# Altium schematic sheet RL-17-003-STP-HB-Mainsheet.SchDoc
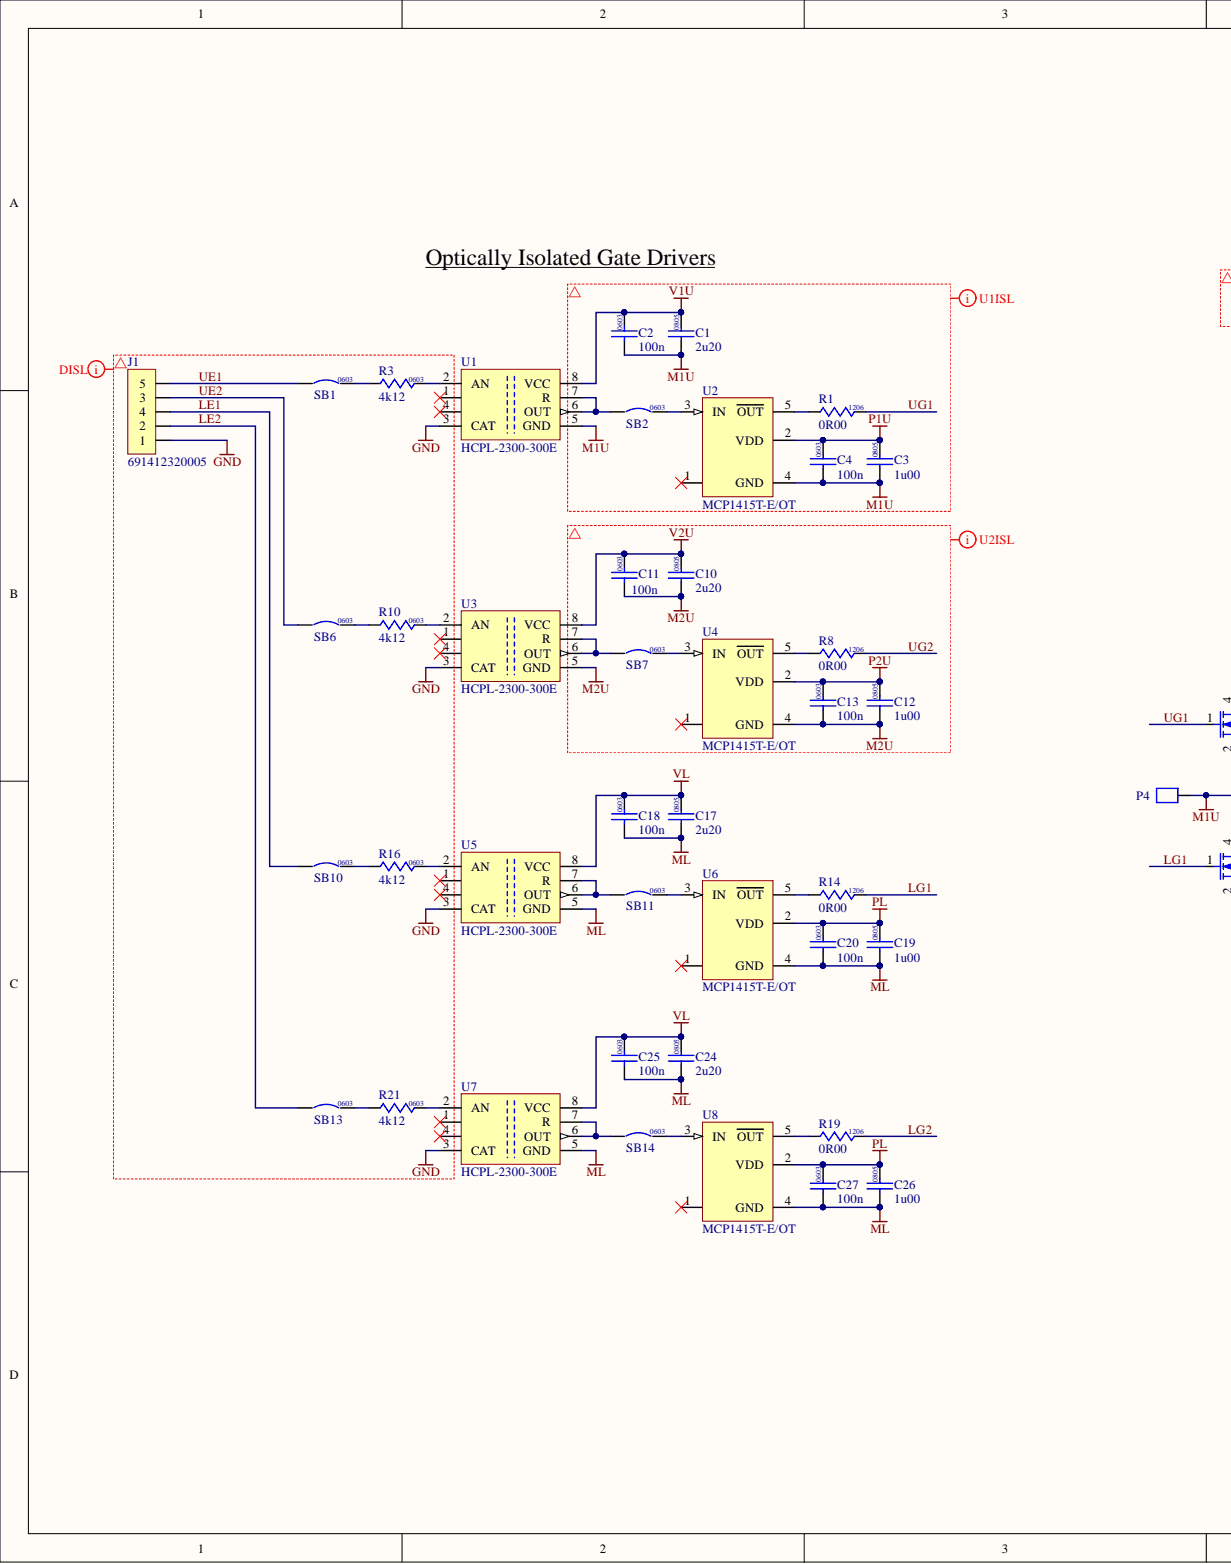
[diagram p0: RL-17-003-STP-HB-Mainsheet.SchDoc, left]
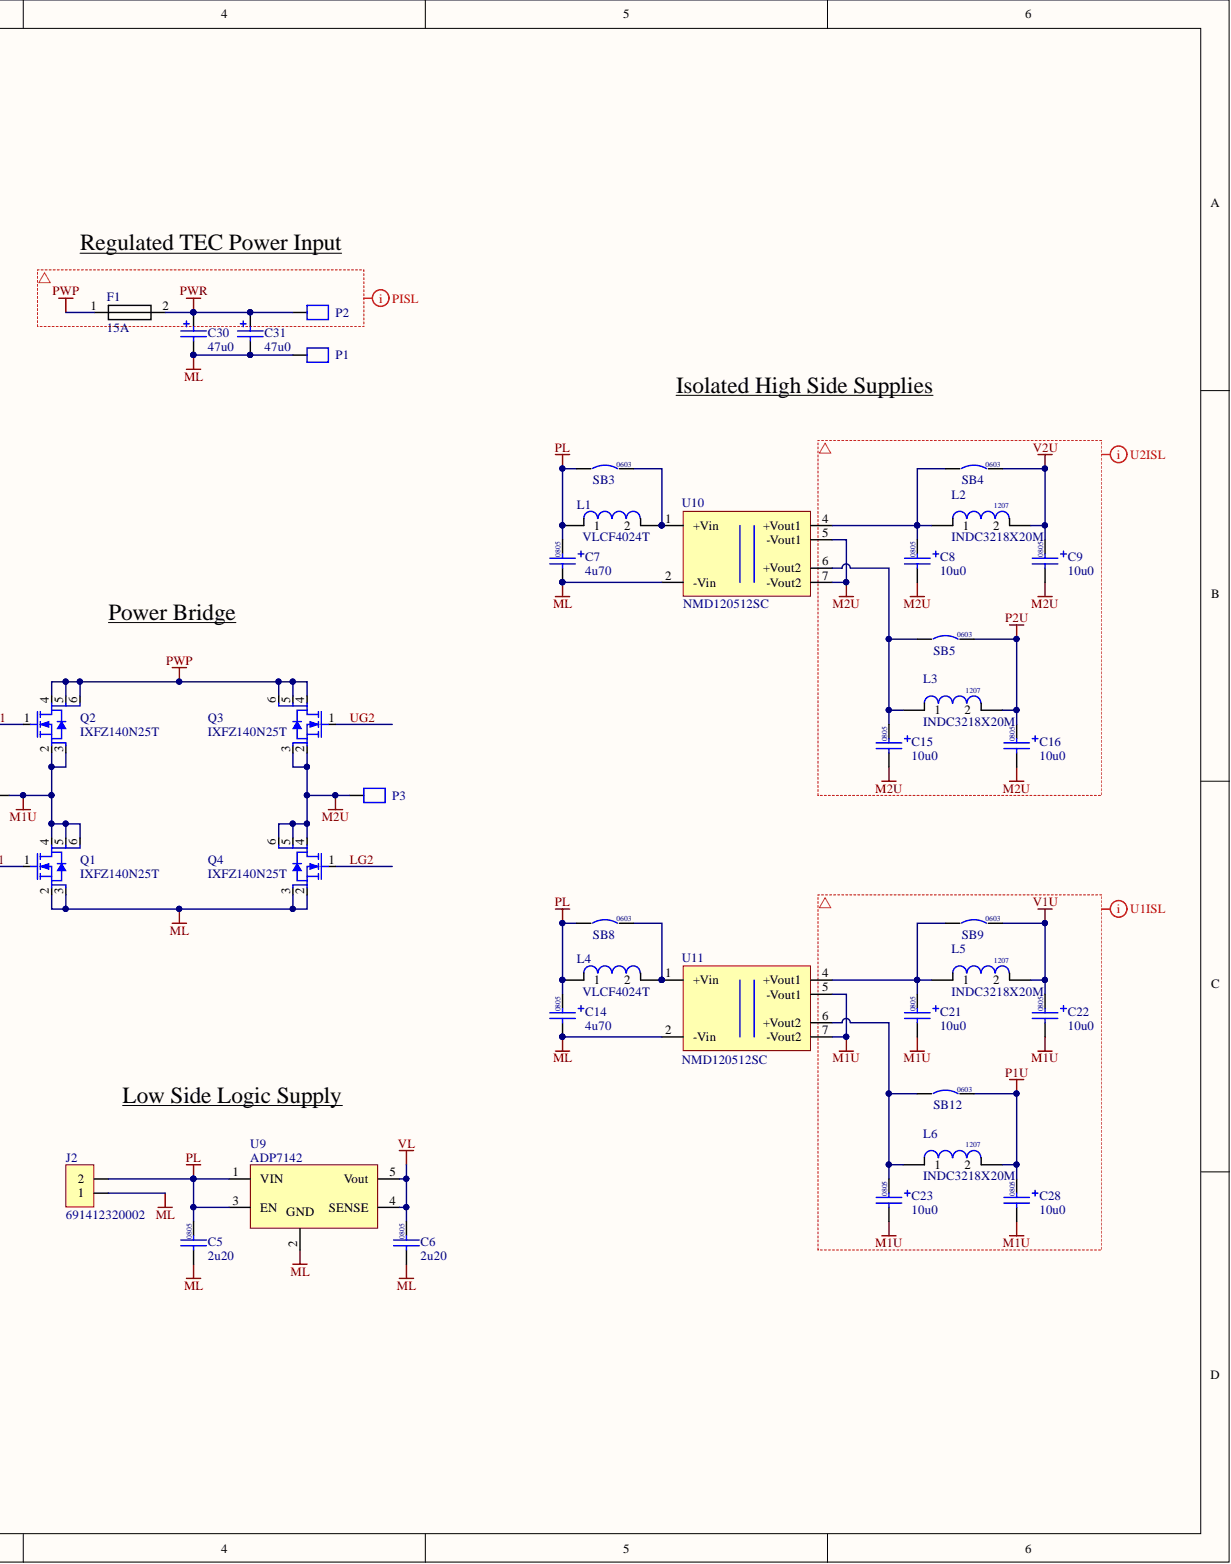
[diagram p1: RL-17-003-STP-HB-Mainsheet.SchDoc, right]

## Parts
C1  2u20  FPrint: 0805  [CAPC2012N]
C2  100n  FPrint: 0603  [CAPC1608N]
C3  1u00  FPrint: 0805  [CAPC2012N]
C4  100n  FPrint: 0603  [CAPC1608N]
C5  2u20  FPrint: 0805  [CAPC2012N]
C6  2u20  FPrint: 0805  [CAPC2012N]
C7  4u70  FPrint: 0805  [CAPC2012N]
C8  10u0  FPrint: 0805  [CAPC2012N]
C9  10u0  FPrint: 0805  [CAPC2012N]
C10  2u20  FPrint: 0805  [CAPC2012N]
C11  100n  FPrint: 0603  [CAPC1608N]
C12  1u00  FPrint: 0805  [CAPC2012N]
C13  100n  FPrint: 0603  [CAPC1608N]
C14  4u70  FPrint: 0805  [CAPC2012N]
C15  10u0  FPrint: 0805  [CAPC2012N]
C16  10u0  FPrint: 0805  [CAPC2012N]
C17  2u20  FPrint: 0805  [CAPC2012N]
C18  100n  FPrint: 0603  [CAPC1608N]
C19  1u00  FPrint: 0805  [CAPC2012N]
C20  100n  FPrint: 0603  [CAPC1608N]
C21  10u0  FPrint: 0805  [CAPC2012N]
C22  10u0  FPrint: 0805  [CAPC2012N]
C23  10u0  FPrint: 0805  [CAPC2012N]
C24  2u20  FPrint: 0805  [CAPC2012N]
C25  100n  FPrint: 0603  [CAPC1608N]
C26  1u00  FPrint: 0805  [CAPC2012N]
C27  100n  FPrint: 0603  [CAPC1608N]
C28  10u0  FPrint: 0805  [CAPC2012N]
C30  47u0  [CAPAE135135X135N]
C31  47u0  [CAPAE135135X135N]
F1  value 15A  [Fuse 5x20]  (hidden comment: 0218015.HXP)
J1  691412320005  [CON-WE-691412320005]
J2  691412320002  [CON-WE-691412320002]
L1  VLCF4024T  [VLCF4024T]
L2  INDC3218X20M  FPrint: 1207  [INDC3218X20M]
L3  INDC3218X20M  FPrint: 1207  [INDC3218X20M]
L4  VLCF4024T  [VLCF4024T]
L5  INDC3218X20M  FPrint: 1207  [INDC3218X20M]
L6  INDC3218X20M  FPrint: 1207  [INDC3218X20M]
P1  FASTON 6.3  [CON-KEYS-4966]  (value not drawn)
P2  FASTON 6.3  [CON-KEYS-4966]  (value not drawn)
P3  FASTON 6.3  [CON-KEYS-4966]  (value not drawn)
P4  FASTON 6.3  [CON-KEYS-4966]  (value not drawn)
Q1  IXFZ140N25T  [PM-DE475-B]
Q2  IXFZ140N25T  [PM-DE475-B]
Q3  IXFZ140N25T  [PM-DE475-B]
Q4  IXFZ140N25T  [PM-DE475-B]
R1  0R00  FPrint: 1206  [RESC3216N]
R3  4k12  FPrint: 0603  [RESC1608N]
R8  0R00  FPrint: 1206  [RESC3216N]
R10  4k12  FPrint: 0603  [RESC1608N]
R14  0R00  FPrint: 1206  [RESC3216N]
R16  4k12  FPrint: 0603  [RESC1608N]
R19  0R00  FPrint: 1206  [RESC3216N]
R21  4k12  FPrint: 0603  [RESC1608N]
SB1  FPrint: 0603  [SB-1608AN]  (hidden comment: BF-SB-0603-1608)
SB2  FPrint: 0603  [SB-1608AN]  (hidden comment: BF-SB-0603-1608)
SB3  FPrint: 0603  [SB-1608AN]  (hidden comment: BF-SB-0603-1608)
SB4  FPrint: 0603  [SB-1608AN]  (hidden comment: BF-SB-0603-1608)
SB5  FPrint: 0603  [SB-1608AN]  (hidden comment: BF-SB-0603-1608)
SB6  FPrint: 0603  [SB-1608AN]  (hidden comment: BF-SB-0603-1608)
SB7  FPrint: 0603  [SB-1608AN]  (hidden comment: BF-SB-0603-1608)
SB8  FPrint: 0603  [SB-1608AN]  (hidden comment: BF-SB-0603-1608)
SB9  FPrint: 0603  [SB-1608AN]  (hidden comment: BF-SB-0603-1608)
SB10  FPrint: 0603  [SB-1608AN]  (hidden comment: BF-SB-0603-1608)
SB11  FPrint: 0603  [SB-1608AN]  (hidden comment: BF-SB-0603-1608)
SB12  FPrint: 0603  [SB-1608AN]  (hidden comment: BF-SB-0603-1608)
SB13  FPrint: 0603  [SB-1608AN]  (hidden comment: BF-SB-0603-1608)
SB14  FPrint: 0603  [SB-1608AN]  (hidden comment: BF-SB-0603-1608)
U1  HCPL-2300-300E  [SOP254P970X440-8N]
U2  MCP1415T-E/OT  [SOT95P280X145-5N]
U3  HCPL-2300-300E  [SOP254P970X440-8N]
U4  MCP1415T-E/OT  [SOT95P280X145-5N]
U5  HCPL-2300-300E  [SOP254P970X440-8N]
U6  MCP1415T-E/OT  [SOT95P280X145-5N]
U7  HCPL-2300-300E  [SOP254P970X440-8N]
U8  MCP1415T-E/OT  [SOT95P280X145-5N]
U9  ADP7142  [SOT95P280X100-5N]
U10  NMD120512SC  [NMD120512SC]
U11  NMD120512SC  [NMD120512SC]

## Nets
GND: J1.1 U1.3(CAT) U3.3(CAT) U5.3(CAT) U7.3(CAT)
M1U: C1.2 C2.2 C3.2 C4.2 C21.2 C22.2 C23.2 C28.2 P4.1 Q1.4 Q1.5 Q1.6 Q2.2 Q2.3 U1.5(GND) U2.4(GND) U11.5(-Vout1) U11.7(-Vout2)
M2U: C8.2 C9.2 C10.2 C11.2 C12.2 C13.2 C15.2 C16.2 P3.1 Q3.2 Q3.3 Q4.4 Q4.5 Q4.6 U3.5(GND) U4.4(GND) U10.5(-Vout1) U10.7(-Vout2)
ML: C5.2 C6.2 C7.2 C14.2 C17.2 C18.2 C19.2 C20.2 C24.2 C25.2 C26.2 C27.2 C30.2 C31.2 J2.1 P1.1 Q1.2 Q1.3 Q4.2 Q4.3 U5.5(GND) U6.4(GND) U7.5(GND) U8.4(GND) U9.2 U10.2(-Vin) U11.2(-Vin)
P1U: C3.1 C4.1 C28.1 L6.2 SB12.2 U2.2(VDD)
P2U: C12.1 C13.1 C16.1 L3.2 SB5.2 U4.2(VDD)
PL: C5.1 C7.1 C14.1 C19.1 C20.1 C26.1 C27.1 J2.2 L1.1 L4.1 SB3.1 SB8.1 U6.2(VDD) U8.2(VDD) U9.1(VIN) U9.3(EN)
PWP: F1.1 Q2.4 Q2.5 Q2.6 Q3.4 Q3.5 Q3.6
PWR: C30.1 C31.1 F1.2 P2.1
V1U: C1.1 C2.1 C22.1 L5.2 SB9.2 U1.8(VCC)
V2U: C9.1 C10.1 C11.1 L2.2 SB4.2 U3.8(VCC)
VL: C6.1 C17.1 C18.1 C24.1 C25.1 U5.8(VCC) U7.8(VCC) U9.4(SENSE) U9.5(Vout)
LE1: J1.4 SB10.1
LE2: J1.2 SB13.1
LG1: Q1.1 R14.2
LG2: Q4.1 R19.2
UE1: J1.5 SB1.1
UE2: J1.3 SB6.1
UG1: Q2.1 R1.2
UG2: Q3.1 R8.2
NetC8_1: C8.1 L2.1 SB4.1 U10.4(+Vout1)
NetC15_1: C15.1 L3.1 SB5.1 U10.6(+Vout2)
NetC21_1: C21.1 L5.1 SB9.1 U11.4(+Vout1)
NetC23_1: C23.1 L6.1 SB12.1 U11.6(+Vout2)
NetL1_2: L1.2 SB3.2 U10.1(+Vin)
NetL4_2: L4.2 SB8.2 U11.1(+Vin)
NetR1_1: R1.1 U2.5(OUT)
NetR3_1: R3.1 SB1.2
NetR3_2: R3.2 U1.2(AN)
NetR8_1: R8.1 U4.5(OUT)
NetR10_1: R10.1 SB6.2
NetR10_2: R10.2 U3.2(AN)
NetR14_1: R14.1 U6.5(OUT)
NetR16_1: R16.1 SB10.2
NetR16_2: R16.2 U5.2(AN)
NetR19_1: R19.1 U8.5(OUT)
NetR21_1: R21.1 SB13.2
NetR21_2: R21.2 U7.2(AN)
NetSB2_1: SB2.1 U2.3(IN)
NetSB2_2: SB2.2 U1.6(OUT) U1.7(R)
NetSB7_1: SB7.1 U4.3(IN)
NetSB7_2: SB7.2 U3.6(OUT) U3.7(R)
NetSB11_1: SB11.1 U6.3(IN)
NetSB11_2: SB11.2 U5.6(OUT) U5.7(R)
NetSB14_1: SB14.1 U8.3(IN)
NetSB14_2: SB14.2 U7.6(OUT) U7.7(R)
N00009:
N00010:
N00011:
N00012:
N00013:
N00014:

Not connected: U1 1(NC) 4(NC); U2 1(NC); U3 1(NC) 4(NC); U4 1(NC); U5 1(NC) 4(NC); U6 1(NC); U7 1(NC) 4(NC); U8 1(NC)

## Library data of the parts
C1 C5 C6 C10 C17 C24 = C-0805-2012: Capacitor, SMD, Case 0805 (2012 Metric); Manufacturer: TDK; Manufacturer Part Number: C2012X7R1H225K125AC; Class1: Passive; Tolerance: 10%; Type: X7R; Voltage: 50V; Class2: Capacitor; Component Kind: Standard; Published: 08JAN17; Supplier 1: Mouser; Supplier 1 Part Number: 810-C2012X7R1H225K; Supplier 2: Digikey; Value: 2u20; Library Name: Rafael.SchLib; Publisher: Rafael
C2 C4 C11 C13 C18 C20 C25 C27 = C-0603-1608: Capacitor, SMD, Case 0603 (1608 Metric); Manufacturer: Murata; Manufacturer Part Number: GRM188R72A104KA35D; Class1: Passive; Tolerance: 10%; Type: X7R; Voltage: 100V; Class2: Capacitor; Component Kind: Standard; Library Name: LIBRARY.SchLib; Published: 08JAN17; Supplier 1: Mouser; Supplier 1 Part Number: 81-GRM188R72A104KA35; Supplier 2: Digikey; Value: 100n; Publisher: Rafael
C3 C12 C19 C26 = C-0805-2012: Capacitor, SMD, Case 0805 (2012 Metric); Manufacturer: Taiyo Yuden; Manufacturer Part Number: TMK212B7105KG-T; Class1: Passive; Tolerance: 10%; Type: X7R; Voltage: 25V; Class2: Capacitor; Component Kind: Standard; Published: 08JAN17; Supplier 1: Mouser; Supplier 1 Part Number: 963-TMK212B7105KG-T; Supplier 2: Digikey; Value: 1u00; Library Name: Rafael.SchLib; Publisher: Rafael
C7 C14 = C-0805-2012: Capacitor, SMD, Case 0805 (2012 Metric); Manufacturer: AVX; Manufacturer Part Number: TAJP475K016RNJ; Class1: Passive; Tolerance: 10%; Type: Tantalum; Voltage: 16V; Class2: Capacitor; Component Kind: Standard; Library Name: LIBRARY.SchLib; Published: 08JAN17; Supplier 1: Mouser; Supplier 1 Part Number: 581-TAJP475K016RNJ; Supplier 2: Digi-Key; Supplier 2 Part Number: 478-7545-1-ND; Value: 4u70; Publisher: Rafael
C8 C9 C15 C16 C21-C23 C28 = C-0805-2012: Capacitor, SMD, Case 0805 (2012 Metric); Manufacturer: AVX; Manufacturer Part Number: TPCR106K016R1800; Class1: Passive; Tolerance: 10%; Type: Tantalum; Voltage: 16V; Class2: Capacitor; Component Kind: Standard; Library Name: LIBRARY.SchLib; Published: 08JAN17; Supplier 1: Mouser; Supplier 1 Part Number: 581-TPCR106K016R1800; Supplier 2: Digi-Key; Supplier 2 Part Number: 478-9014-1-ND; Value: 10u0; Publisher: Rafael
C30 C31 = C-AE-H13: Aluminum Electrolytic Capacitor, SMD, Case H13, Height 13.50mm, Can Dia 12.5mm, Footprint 13.50x13.50mm; Manufacturer: Panasonic; Manufacturer Part Number: EEV-TG2A470Q; Class1: Passive; Tolerance: 20%; Type: Aluminum Electrolytic Capacitors; Voltage: 100V; Class2: Capacitor; Component Kind: Standard; Published: 10DEC17; Supplier 1: Mouser; Supplier 1 Part Number: 667-EEV-TG2A470Q; Supplier 2: Digikey; Value: 47u0; Library Name: Rafael.SchLib; Publisher: Rafael
F1 = Fuse 5x20: Cartridge Fuses 250V 15A Time Lag (Slo-Blo); Manufacturer: Littelfuse; Class1: Passive; Class2: Fuse; FPrint: 5 mm x 20 mm; Type: Time Delay / Slow Blow; Component Kind: Standard; Library Name: LIBRARY.SchLib; Manufacturer Part Number: 0218015.HXP; Published: 21.4.2017; Supplier 1: Mouser; Supplier 1 Part Number: 576-0218015.HXP; Supplier 2: Digi-Key; Supplier 2 Part Number: F2426-ND; Voltage: 250V; Publisher: Tomáš Štefanička
J1 = TB-WE-691412320005: Fixed Terminal Blocks WR-TBL Md4123 3.81mm Cable Screwless; Manufacturer: Würth Elektronik; Class1: Active; Value: 4A; Voltage: 300V; Class2: Terminal Block; Component Kind: Standard; Library Name: LIBRARY.SchLib; Manufacturer Part Number: 691412320005; Published: 19.11.2017; Supplier 1: Mouser; Supplier 1 Part Number: 710-691412320005; Supplier 2: Digi-Key; Supplier 2 Part Number: 732-11130-ND; Type: 5 PIN; Publisher: Rafael
J2 = TB-WE-691412320002: Terminal Block, 2 Pos, 1 Row, TH, Pitch 3.81mm, 45° Cable Entry, 16~30 AWG, Screwless, Push Button; Manufacturer: Würth Elektronik; Class1: Passive; Class2: Terminal Block; Component Kind: Standard; Manufacturer Part Number: 691412320002; Published: 02SEP17; Supplier 1: Mouser; Supplier 1 Part Number: 710-691412320002; Supplier 2: Digikey; Library Name: Rafael.SchLib; Publisher: Rafael
L1 L4 = VLCF4024T: Fixed Inductors 22uH 0.65A 280mOhms 20%; Manufacturer: TDK; Manufacturer Part Number: VLCF4020T-220MR56; Class1: Passive; FPrint: 4020 metric; Type: Wound Ferrite Inductor; Value: 22u0; Class2: Inductor; Component Kind: Standard; Library Name: LIBRARY.SchLib; Published: 19.11.2017; Supplier 1: Mouser; Supplier 1 Part Number: 810-VLCF4020T220MR56; Supplier 2: Digi-Key; Supplier 2 Part Number: 445-3188-1-ND; Tolerance: 20%; Publisher: Tomáš Štefanička
L2 L3 L5 L6 = INDC3218X20M: Fixed Inductors 1207 1000uH 35.1Ohms +/-10%Tol 39mA; Manufacturer: Taiyo Yuden; Manufacturer Part Number: LB3218T102K; Class1: Passive; Tolerance: 10%; Type: Wire-Wound Chip Inductors; Value: 1m00; Class2: Inductor; Component Kind: Standard; Published: 14.11.2017; Supplier 1: Mouser; Supplier 1 Part Number: 963-LB3218T102K; Supplier 2: Digi-Key; Supplier 2 Part Number: 587-2041-1-ND; Library Name: Tomáš.SchLib; Publisher: Tomáš Štefanička
P1-P4 = FASTON 6.3: Faston 6.3mm, 90°, THT; Manufacturer: Keystone; Manufacturer Part Number: 4966; Class1: Passive; Class2: Terminal; Component Kind: Standard; Published: 04DEC17; Supplier 1: Mouser; Supplier 1 Part Number: 534-4966; Supplier 2: Digikey; Type: 6.3mm / 90°; Library Name: Tomáš.SchLib; Publisher: Rafael
Q1-Q4 = FET-IXFZ140N25T: N-MOSFET, Power, Vds 250V, Vgs ±20V, Id 100A (Tc), Pd 445W (Tc), Vgsth 2.5V~5V, Avalanche Rated, Fast Intrinsic Diode; Manufacturer: IXYS; Class1: Active; Class2: N-MOSFET; Component Kind: Standard; Manufacturer Part Number: IXFZ140N25T; Published: 22NOV17; Supplier 1: Mouser; Supplier 2: Digikey; Library Name: Rafael.SchLib; Publisher: Rafael
R1 R8 R14 R19 = R-1206-3216: Resistor, SMD, Case 1206 (3216 Metric); Manufacturer: Yageo; Manufacturer Part Number: RC1206JR-070RL; Class1: Passive; Tolerance: 5%; Voltage: 200V; Class2: Resistor; Component Kind: Standard; Library Name: LIBRARY.SchLib; Published: 08JAN17; Supplier 1: Mouser; Supplier 1 Part Number: 603-RC1206JR-070RL; Supplier 2: Digi-Key; Type: Thick Film; Value: 0R00; Publisher: Rafael
R3 R10 R16 R21 = R-0603-1608: Resistor, SMD, Case 0603 (1608 Metric); Manufacturer: Yageo; Manufacturer Part Number: RC0603FR-074K12L; Class1: Passive; Tolerance: 1%; Voltage: 75V; Class2: Resistor; Component Kind: Standard; Library Name: LIBRARY.SchLib; Published: 08JAN17; Supplier 1: Mouser; Supplier 1 Part Number: 603-RC0603FR-074K12L; Supplier 2: Digi-Key; Supplier 2 Part Number: 311-4.12KHRCT-ND; Type: General Purpose Resistor; Value: 4k12; Publisher: Rafael
SB1-SB14 = BF-SB-0603-1608: Solder Bridge, 0603 (1608 Metric) Footprint Replacement; Class1: Passive; Class2: Board Feature; Component Kind: Standard; Published: 10AUG17; Library Name: Rafael.SchLib; Publisher: Rafael
U1 U3 U5 U7 = ISO-HCPL-2300-X: Optocoupler, Logic Output, 3.75kV Isolation, AlGaAs Photon Emitting Diode Input, 5Mbps Data Rate, 4.75V~5.25V Supply, 0.5mA Min High Level Input Current; Manufacturer: Avago; Class1: Active; Class2: Optocoupler; Component Kind: Standard; Manufacturer Part Number: HCPL-2300-300E; Published: 11DEC17; Supplier 1: Mouser; Supplier 1 Part Number: 630-HCPL-2300-300E; Supplier 2: Digikey; Library Name: Rafael.SchLib; Publisher: Rafael
U2 U4 U6 U8 = GDRV-MCP1415T-E/OT: Gate Driver, 2V~60V Supply, Adjustable Constant Current Sink/Source, 75mA Max Output, PWM Brightness Regulation, OTP, RPP, SCP; Manufacturer: Microchip; Class1: Active; Class2: Gate Driver; Component Kind: Standard; Manufacturer Part Number: MCP1415T-E/OT; Published: 01DEC17; Supplier 1: Mouser; Supplier 1 Part Number: 579-MCP1415T-E/OT; Supplier 2: Digikey; Library Name: Rafael.SchLib; Publisher: Rafael
U9 = ADP7142: LDO Voltage Regulators 40V 200mA LDO 5.0Vo; Manufacturer: Analog Devices Inc.; Manufacturer Part Number: ADP7142AUJZ-5.0-R7; Class1: Active; FPrint: TSOT-25-5; Class2: LDO; Component Kind: Standard; Published: 14.11.2017; Supplier 1: Mouser; Supplier 1 Part Number: 584-ADP7142AUJZ5.0R7; Supplier 2: Digi-Key; Supplier 2 Part Number: ADP7142AUJZ-5.0-R7CT-ND; Type: CMOS Linear Voltage Regulator; Voltage: 40V; Library Name: Tomáš.SchLib; Publisher: Tomáš Štefanička
U10 U11 = NMD120512SC: Isolated DC/DC Converters 1W TWIN 12-5/15 SIP Twin Output; Manufacturer: Murata; Class1: Active; Voltage: 5V / 12V; Class2: DC/DC Converter; Component Kind: Standard; Library Name: LIBRARY.SchLib; Manufacturer Part Number: NMD120512SC; Published: 14.11.2017; Supplier 1: Mouser; Supplier 1 Part Number: 580-NMD120512SC; Supplier 2: Digi-Key; Supplier 2 Part Number: NMD120512SC-ND; Type: Isolated DC/DC Converters 1W TWIN 12-5/15 SIP Twin Output; Publisher: Tomáš Štefanička
